# Altium schematic sheet Main.SchDoc(Main)
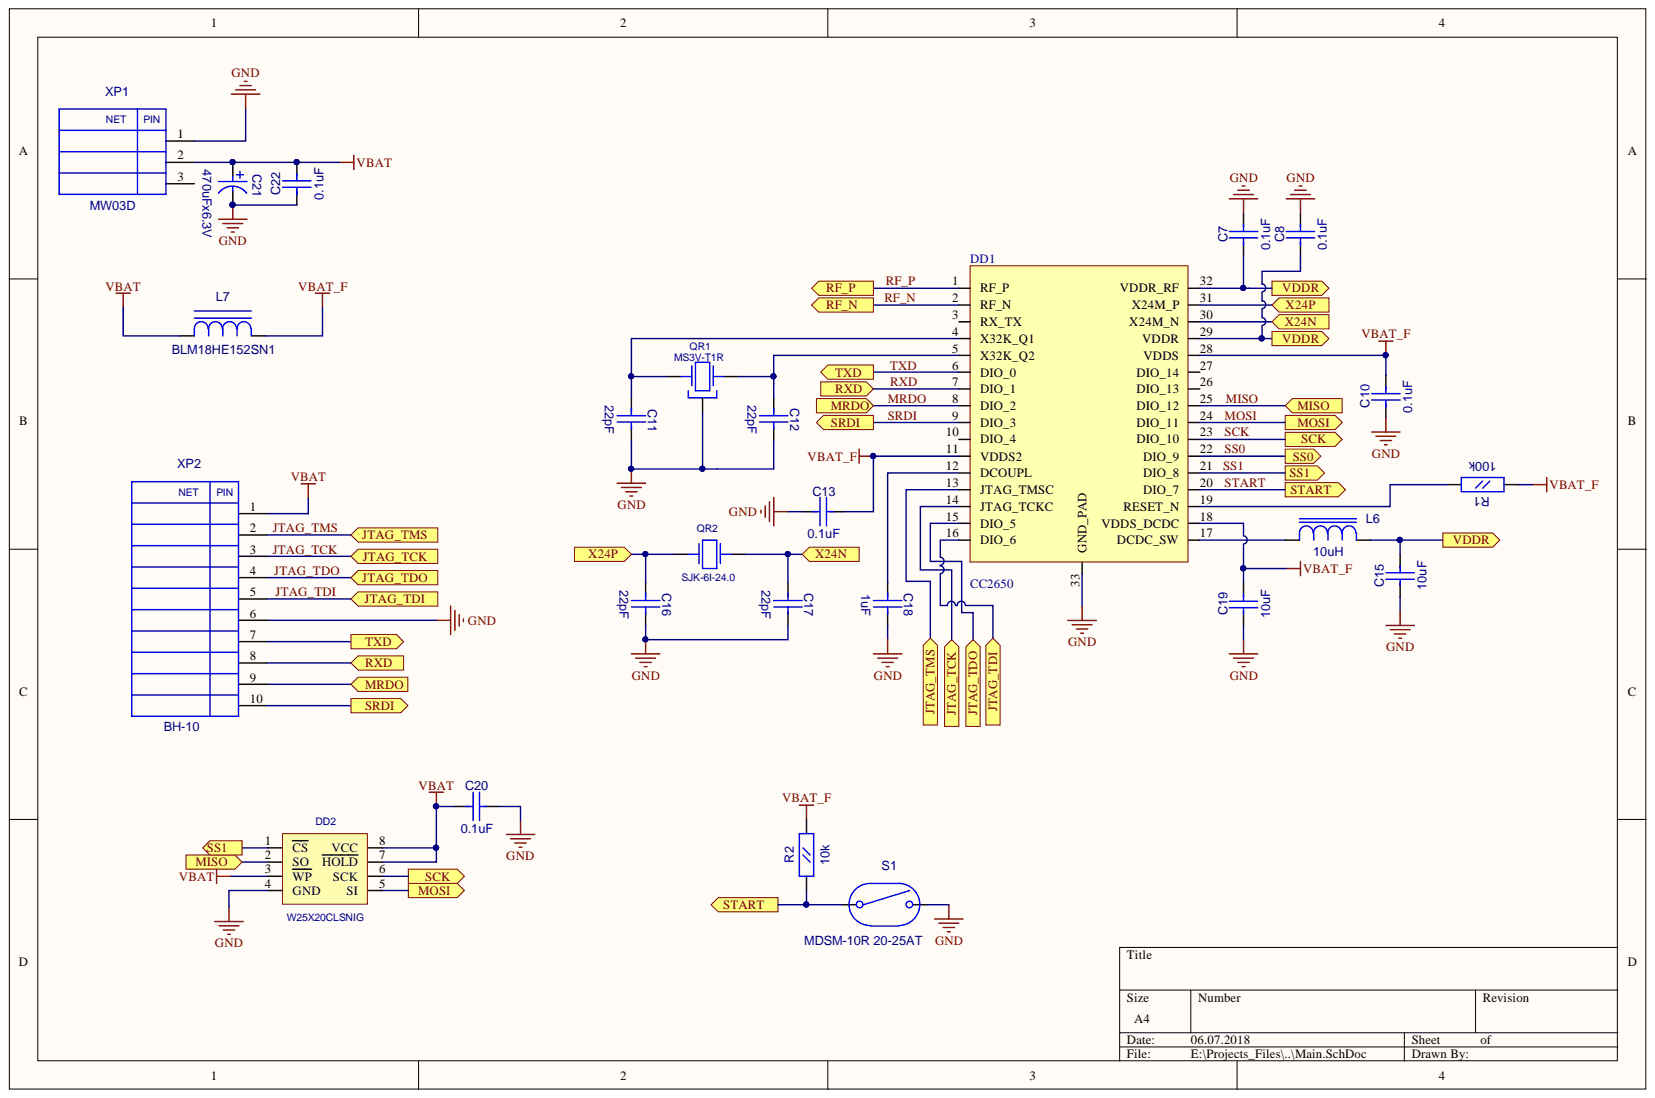
[diagram p0: Main.SchDoc]

## Parts
C7  0.1uF  [CC0603]
C8  0.1uF  [CC0603]
C10  0.1uF  [CC0603]
C11  22pF  [CC0603]
C12  22pF  [CC0603]
C13  0.1uF  [CC0603]
C15  10uF  [CC0805]
C16  22pF  [CC0603]
C17  22pF  [CC0603]
C18  1uF  [CC0603]
C19  10uF  [CC0805]
C20  0.1uF  [CC0603]
C21  470uFx6.3V  [TAJ-D-1M]
C22  0.1uF  [CC0603]
DD1  CC2650  [QFN32_TI]
DD2  W25X20CLSNIG  [EIAJ_SO8]
L6  10uH  [RC0805]
L7  BLM18HE152SN1  [L0603]
QR1  MS3V-T1R  [MS3V-T1R]
QR2  SJK-6I-24.0  [AC5032_2]
R1  100k  [RC0603]
R2  10k  [RC0603]
S1  MDSM-10R 20-25AT  [MDSM-10R]
XP1  MW03D  [MW03D]
XP2  BH-10  [BH-10]

## Ports
JTAG_TCK, JTAG_TDI, JTAG_TDO, JTAG_TMS, MISO, MOSI, MRDO, RF_N, RF_P, RXD, SCK, SRDI, SS0, SS1, START, TXD, VDDR, X24N, X24P

## Nets
GND: C7.2 C8.2 C10.1 C11.2 C12.2 C13.1 C15.1 C16.2 C17.2 C18.2 C19.1 C20.2 C21.2 C22.1 DD1.33(GND_PAD) DD2.4(GND) QR1.3 S1.2 XP1.1 XP2.6
VBAT: C20.1 C21.1 C22.2 DD2.3(WP) DD2.7(HOLD) DD2.8(VCC) L7.1 XP1.2 XP2.1
VBAT_F: C10.2 C13.2 C19.2 DD1.11(VDDS2) DD1.18(VDDS_DCDC) DD1.28(VDDS) L7.2 R1.1 R2.2
JTAG_TCK: DD1.14(JTAG_TCKC) XP2.3 ; port JTAG_TCK
JTAG_TDI: DD1.16(DIO_6) XP2.5 ; port JTAG_TDI
JTAG_TDO: DD1.15(DIO_5) XP2.4 ; port JTAG_TDO
JTAG_TMS: DD1.13(JTAG_TMSC) XP2.2 ; port JTAG_TMS
MISO: DD1.25(DIO_12) DD2.2(SO) ; port MISO
MOSI: DD1.24(DIO_11) DD2.5(SI) ; port MOSI
MRDO: DD1.8(DIO_2) XP2.9 ; port MRDO
RF_N: DD1.2(RF_N) ; port RF_N
RF_P: DD1.1(RF_P) ; port RF_P
RXD: DD1.7(DIO_1) XP2.8 ; port RXD
SCK: DD1.23(DIO_10) DD2.6(SCK) ; port SCK
SRDI: DD1.9(DIO_3) XP2.10 ; port SRDI
SS0: DD1.22(DIO_9) ; port SS0
SS1: DD1.21(DIO_8) DD2.1(CS) ; port SS1
START: DD1.20(DIO_7) R2.1 S1.1 ; port START
TXD: DD1.6(DIO_0) XP2.7 ; port TXD
VDDR: C7.1 C8.1 C15.2 DD1.29(VDDR) DD1.32(VDDR_RF) L6.2 ; port VDDR
X24N: C17.1 DD1.30(X24M_N) QR2.2 ; port X24N
X24P: C16.1 DD1.31(X24M_P) QR2.1 ; port X24P
NetC11_1: C11.1 DD1.4(X32K_Q1) QR1.2
NetC12_1: C12.1 DD1.5(X32K_Q2) QR1.1
NetC18_1: C18.1 DD1.12(DCOUPL)
NetDD1_17: DD1.17(DCDC_SW) L6.1
NetDD1_19: DD1.19(RESET_N) R1.2

Not connected: DD1 3(RX_TX) 10(DIO_4) 26(DIO_13) 27(DIO_14); XP1 3

## Library data of the parts
C7 C8 C10-C13 C16-C18 C20 C22 = CC0603: Description: CC0603; Component Kind: Standard; Library Name: gprs_modem_2.SchLib
  C7 C8 C10 C13 C20 C22: Value: 0.1uF
  C11 C12 C16 C17: Value: 22pF
  C18: Value: 1uF
C15 C19 = CC0805: Value: 10uF; Description: CC0805; Component Kind: Standard; Library Name: gprs_modem_2.SchLib
C21 = TAJ-D: Value: 470uFx6.3V; Description: TAJ-D; Component Kind: Standard; Library Name: gprs_modem_2.SchLib
DD1 = CC2650RHB: CC2650RHBT; Value: CC2650; Component Kind: Standard; Library Name: gprs_modem_2.SchLib
DD2 = S25FL016A0LMFI01: Value: W25X20CLSNIG; Description: S25FL016A0LMFI01; Component Kind: Standard; Library Name: gprs_modem_2.SchLib
L6 = L0805: Value: 10uH; Description: L0805; Component Kind: Standard; Library Name: gprs_modem_2.SchLib
L7 = L0603: Value: BLM18HE152SN1; Description: L0603; Component Kind: Standard; Library Name: gprs_modem_2.SchLib
QR1 = MS3V-T1R: CRYSTAL_32768 Hz; Component Kind: Standard; Library Name: gprs_modem_2.SchLib
QR2 = AC5032_2: AC5032/2; Value: SJK-6I-24.0; Component Kind: Standard; Library Name: gprs_modem_2.SchLib
R1 R2 = RC0603: Description: RC0603; Component Kind: Standard; Library Name: gprs_modem_2.SchLib
  R1: Value: 100k
  R2: Value: 10k
S1 = MDSM-10R: Switch, Single Pole, Single Throw, Normally Open; Value: {Value}; Component Kind: Standard; Library Name: gprs_modem.SchLib
XP1 = MW03D: Description: MW03D; Component Kind: Standard; Library Name: gprs_modem_2.SchLib
XP2 = BH-10: Description: BH-10; Component Kind: Standard; Library Name: gprs_modem_2.SchLib
